# Altium schematic sheet RSSI.SchDoc(U_RSSI)
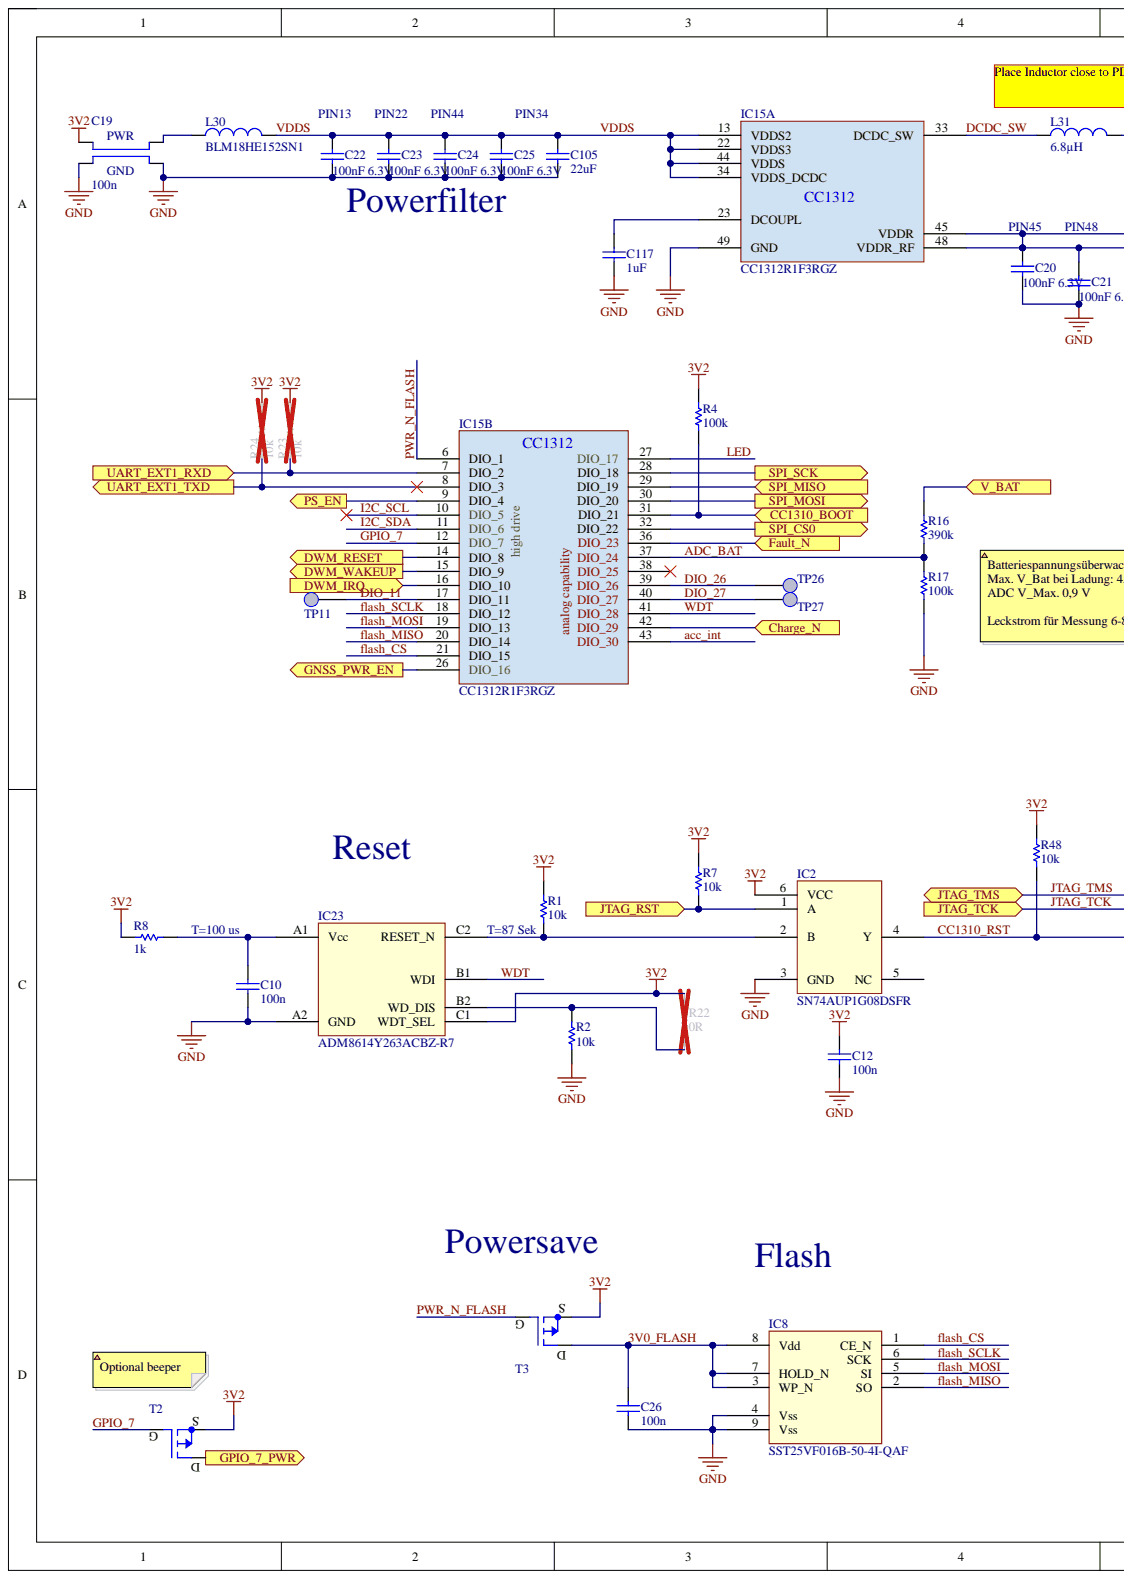
[diagram p0: RSSI.SchDoc, left]
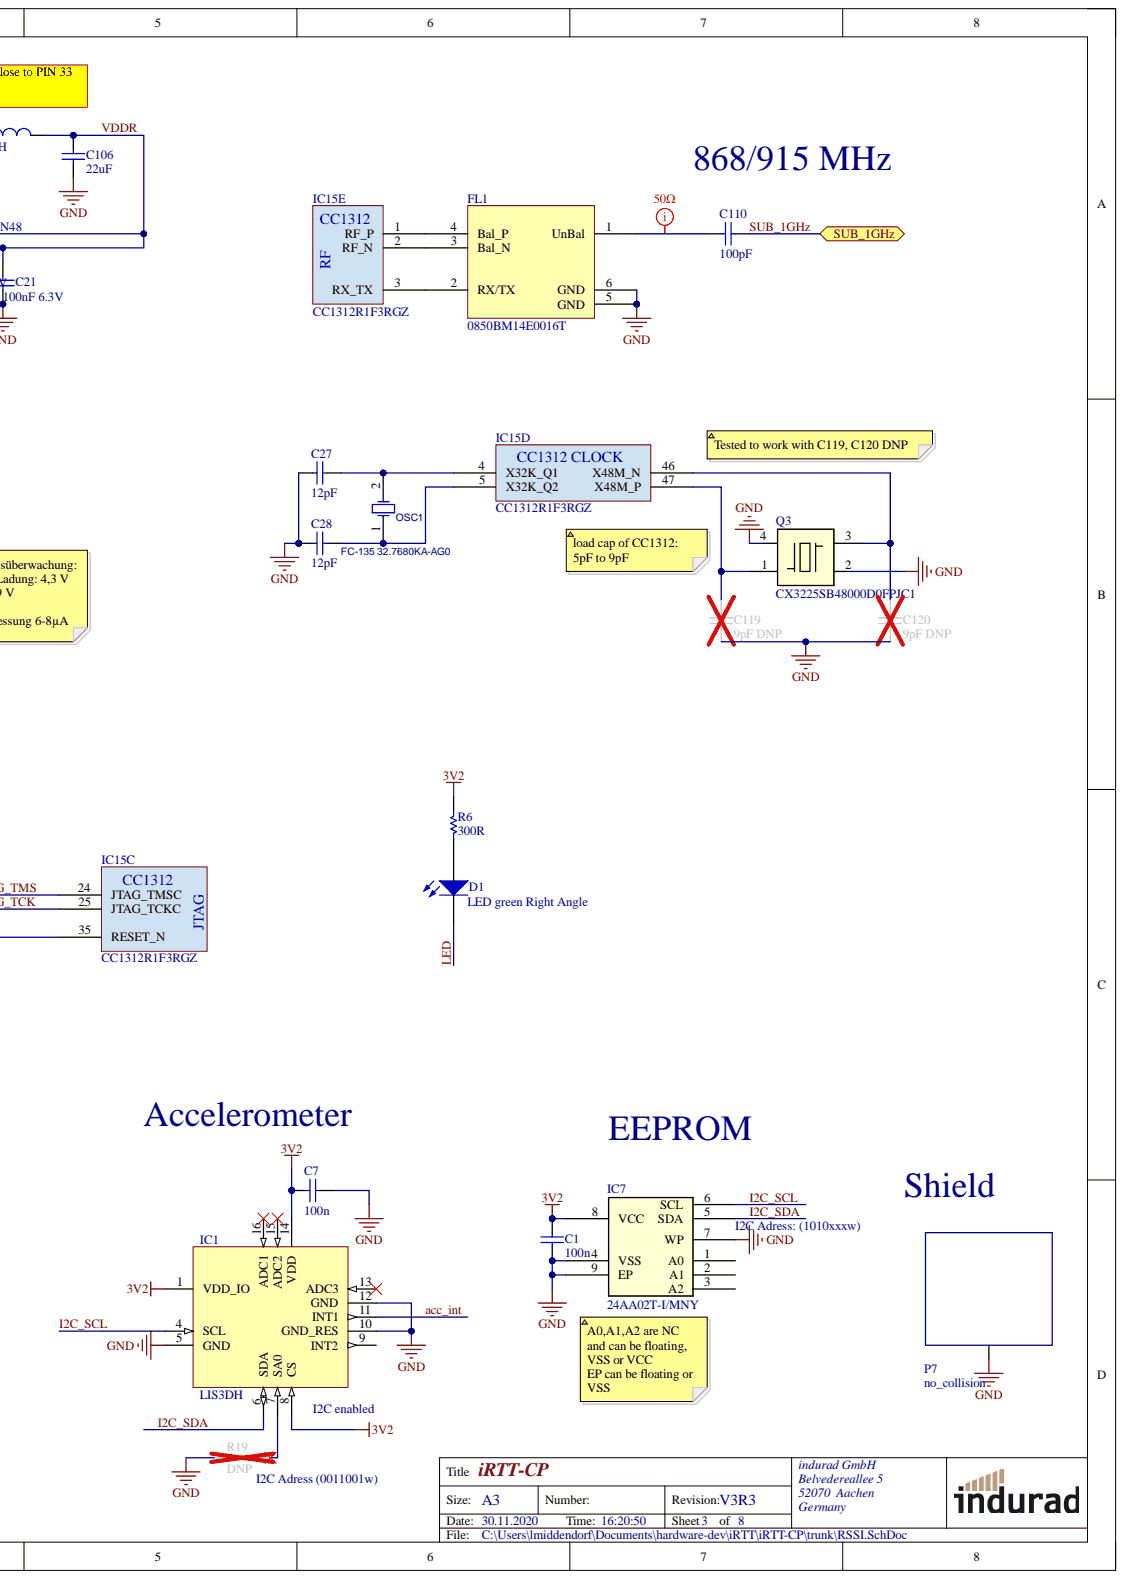
[diagram p1: RSSI.SchDoc, right]

## Parts
C1  100n  [0402_N_(1005)]
C7  100n  [0402_N_(1005)]
C10  100n  [0402_N_(1005)]
C12  100n  [0402_N_(1005)]
C19  100n  [0603_N_(1608) - 4_POL]
C20  100nF 6.3V  [0201_N_(0603)]
C21  100nF 6.3V  Voltage - Rated: 6.3V  [0201_N_(0603)]
C22  100nF 6.3V  [0201_N_(0603)]
C23  100nF 6.3V  Voltage - Rated: 6.3V  [0201_N_(0603)]
C24  100nF 6.3V  Voltage - Rated: 6.3V  [0201_N_(0603)]
C25  100nF 6.3V  Voltage - Rated: 6.3V  [0201_N_(0603)]
C26  100n  [0402_N_(1005)]
C27  12pF  [0402_N_(1005)]
C28  12pF  [0402_N_(1005)]
C105  22uF  Voltage - Rated: 6.3V  [0603_N_(1608)]
C106  22uF  [0603_N_(1608)]
C110  100pF  [0402_N_(1005)]
C117  1uF  [0402_N_(1005)]
C119  9pF DNP  value 9pF  Capacitance: 9pF  [0402_N_(1005)]
C120  9pF DNP  value 9pF  Capacitance: 9pF  [0402_N_(1005)]
D1  LED green Right Angle  [Right Angle Surface LED - HighDensity]
FL1  0850BM14E0016T  [0603 6pol]
IC1  LIS3DH  [LGA16_3mmx3mmx1mm]
IC2  SN74AUP1G08DSFR  [SON6]
IC7  24AA02T-I/MNY  [8-TDFN - EP]
IC8  SST25VF016B-50-4I-QAF  [WDFN-8 WSON-8]
IC15  CC1312R1F3RGZ  [QFN48]  (parts A,B,C,D,E)
IC23  ADM8614Y263ACBZ-R7  [6-WFBGA]
L30  BLM18HE152SN1  [INDC_0603_L_(1608)]
L31  6.8µH  [INDC_0805_L_(2012)]
OSC1  FC-135 32.7680KA-AG0  [FC-135R]
P7  ClassName: no_collision  [SMD Shielding Cabinet 15X15 with clips_CC1312]  (hidden comment: SMD Shield with clips 15x15x2x0,20)
Q3  CX3225SB48000D0FPJC1  [Quarz-MT]
R1  10k  [0402_N_(1005)]
R2  10k  [0402_N_(1005)]
R4  100k  [0402_N_(1005)]
R6  300R  [0402_N_(1005)]
R7  10k  [0402_N_(1005)]
R8  1k  [0402_N_(1005)]
R16  390k  [0603_N_(1608)]
R17  100k  [0402_N_(1005)]
R19  DNP  [0402_N_(1005)]
R22  0R  [0603_N_(1608)]
R23  10k  [0402_N_(1005)]
R24  10k  [0402_N_(1005)]
R48  10k  [0402_N_(1005)]
T2  P-MOSFET  [SOT883]  (value not drawn)
T3  P-MOSFET  [SOT883]  (value not drawn)
TP11  TP  [TP1.0d0.7]  (no BOM)
TP26  TP  [TP1.0d0.7]  (no BOM)
TP27  TP  [TP1.0d0.7]  (no BOM)

## Ports
CC1310_BOOT, Charge_N, DWM_IRQ, DWM_RESET, DWM_WAKEUP, Fault_N, GNSS_PWR_EN, GPIO_7_PWR, JTAG_RST, JTAG_TCK, JTAG_TMS, PS_EN, SPI_CS0, SPI_MISO, SPI_MOSI, SPI_SCK, SUB_1GHz, UART_EXT1_RXD, UART_EXT1_TXD, V_BAT

## Nets
3V2: C1.2 C7.2 C12.2 C19.1(PWR) IC1.1(VDD_IO) IC1.8(CS) IC1.14(VDD) IC2.6(VCC) IC7.8(VCC) IC23.C1(WDT_SEL) R1.1 R4.2 R6.2 R7.2 R8.1 R22.2 R23.2 R24.2 R48.2 T2.2 T3.2
GND: C1.1 C7.1 C10.1 C12.1 C19.2 C19.4 C20.2 C21.2 C22.2 C23.2 C24.2 C25.2 C26.1 C27.1 C28.1 C105.1 C106.1 C117.1 C119.1 C120.1 FL1.5(GND) FL1.6(GND) IC1.5(GND) IC1.10(GND_RES) IC1.12(GND) IC2.3(GND) IC7.4(VSS) IC7.7(WP) IC7.9(EP) IC8.4(Vss) IC8.9(Vss) IC15.49(GND) IC23.A2(GND) P7.1 Q3.2 Q3.4 R2.2 R17.1 R19.2
3V0_FLASH: C26.2 IC8.3(WP_N) IC8.7(HOLD_N) IC8.8(Vdd) T3.3
acc_int: IC1.11(INT1) IC15.43(DIO_30)
ADC_BAT: IC15.37(DIO_24) R16.1 R17.2
CC1310_BOOT: IC15.31(DIO_21) R4.1 ; port CC1310_BOOT
CC1310_RST: IC2.4(Y) IC15.35(RESET_N) R48.1
Charge_N: IC15.42(DIO_29) ; port Charge_N
DCDC_SW: IC15.33(DCDC_SW) L31.1
DIO_11: IC15.17(DIO_11) TP11.1
DIO_26: IC15.39(DIO_26) TP26.1
DIO_27: IC15.40(DIO_27) TP27.1
DWM_IRQ: IC15.16(DIO_10) ; port DWM_IRQ
DWM_RESET: IC15.14(DIO_8) ; port DWM_RESET
DWM_WAKEUP: IC15.15(DIO_9) ; port DWM_WAKEUP
Fault_N: IC15.36(DIO_23) ; port Fault_N
flash_CS: IC8.1(CE_N) IC15.21(DIO_15)
flash_MISO: IC8.2(SO) IC15.20(DIO_14)
flash_MOSI: IC8.5(SI) IC15.19(DIO_13)
flash_SCLK: IC8.6(SCK) IC15.18(DIO_12)
GNSS_PWR_EN: IC15.26(DIO_16) ; port GNSS_PWR_EN
GPIO_7: IC15.12(DIO_7) T2.1
GPIO_7_PWR: T2.3 ; port GPIO_7_PWR
I2C_SCL: IC1.4(SCL) IC7.6(SCL) IC15.10(DIO_5)
I2C_SDA: IC1.6(SDA) IC7.5(SDA) IC15.11(DIO_6)
JTAG_RST: IC2.1(A) R7.1 ; port JTAG_RST
JTAG_TCK: IC15.25(JTAG_TCKC) ; port JTAG_TCK
JTAG_TMS: IC15.24(JTAG_TMSC) ; port JTAG_TMS
LED: D1.1 IC15.27(DIO_17)
PS_EN: IC15.9(DIO_4) ; port PS_EN
PWR_N_FLASH: IC15.6(DIO_1) T3.1
SPI_CS0: IC15.32(DIO_22) ; port SPI_CS0
SPI_MISO: IC15.29(DIO_19) ; port SPI_MISO
SPI_MOSI: IC15.30(DIO_20) ; port SPI_MOSI
SPI_SCK: IC15.28(DIO_18) ; port SPI_SCK
SUB_1GHz: C110.1 ; port SUB_1GHz
UART_EXT1_RXD: IC15.7(DIO_2) R23.1 ; port UART_EXT1_RXD
UART_EXT1_TXD: IC15.8(DIO_3) R24.1 ; port UART_EXT1_TXD
V_BAT: R16.2 ; port V_BAT
VDDR: C20.1 C21.1 C106.2 IC15.45(VDDR) IC15.48(VDDR_RF) L31.2
VDDS: C22.1 C23.1 C24.1 C25.1 C105.2 IC15.13(VDDS2) IC15.22(VDDS3) IC15.34(VDDS_DCDC) IC15.44(VDDS) L30.2
WDT: IC15.41(DIO_28) IC23.B1(WDI)
NetC10_2: C10.2 IC23.A1(Vcc) R8.2
NetC19_3: C19.3 L30.1
NetC27_2: C27.2 IC15.4(X32K_Q1) OSC1.2
NetC28_2: C28.2 IC15.5(X32K_Q2) OSC1.1
NetC110_2: C110.2 FL1.1(UnBal)
NetC117_2: C117.2 IC15.23(DCOUPL)
NetC119_2: C119.2 IC15.47(X48M_P) Q3.1
NetC120_2: C120.2 IC15.46(X48M_N) Q3.3
NetD1_2: D1.2 R6.1
NetFL1_2: FL1.2(RX/TX) IC15.3(RX_TX)
NetFL1_3: FL1.3(Bal_N) IC15.2(RF_N)
NetFL1_4: FL1.4(Bal_P) IC15.1(RF_P)
NetIC1_7: IC1.7(SA0) R19.1
NetIC1_9: IC1.9(INT2)
NetIC1_13: IC1.13(ADC3)
NetIC1_15: IC1.15(ADC2)
NetIC1_16: IC1.16(ADC1)
NetIC2_2: IC2.2(B) IC23.C2(RESET_N) R1.2
NetIC2_5: IC2.5(NC)
NetIC7_1: IC7.1(A0)
NetIC7_2: IC7.2(A1)
NetIC7_3: IC7.3(A2)
NetIC15_38: IC15.38(DIO_25)
NetIC23_B2: IC23.B2(WD_DIS) R2.1 R22.1

## Library data of the parts
C1 C7 C10 C12 C26 = Cap: Capacitor; Manufacturer: Murata Electronics North America; Manufacturer Part Number: GRM155R70J104KA01D; Capacitance: 0.1µF; Temperature Coefficient: X7R; Tolerance: ±10%; Voltage - Rated: 6.3V; Applications: General Purpose; Category: Capacitors; Component Kind: Standard; ComponentLink1Description: http://www.murata.com/~/media/webrenewal/support/library/catalog/products/capacitor/mlcc/c02e.ashx; ComponentLink1URL: http://www.murata.com/~/media/webrenewal/support/library/catalog/products/capacitor/mlcc/c02e.ashx; Features: -; Height - Seated (Max): -; Lead Spacing: -; Mounting Type: Surface Mount, MLCC; Operating Temperature: -55°C ~ 125°C; Package / Case: 0402 (1005 Metric); Packaging: Cut Tape (CT); Pricing 1: 1=0.1, 10=0.025, 50=0.0136, 100=0.0114, 250=0.00936, 500=0.008, 1000=0.00622, 5000=0.00519 (EUR); Ratings: -; RoHS: RoHS Compliant; Signal Integrity: Cap; Simulation: CAP; Size / Dimension: 0.039" L x 0.020" W (1.00mm x 0.50mm); Stock 1: 430852; Supplier 1: Digi-Key; Supplier Part Number 1: 490-6319-1-ND; Thickness (Max): 0.022" (0.55mm); Value: 100n; Library Name: Indurad.SchLib
C19 = Cap 4 Pol 16V: Capacitor 4 pol 16V; Manufacturer: TDK Corporation; Manufacturer Part Number: YFF18PC1C104M; Capacitance: 0.1µF; Current: 4A; Category: Filters; Component Kind: Standard; ComponentLink1Description: https://product.tdk.com/info/en/catalog/datasheets/emc_esr_yff-p_en.pdf; ComponentLink1URL: https://product.tdk.com/info/en/catalog/datasheets/emc_esr_yff-p_en.pdf; DC Resistance (DCR): -; Height (Max): 0.035" (0.90mm); Operating Temperature: -55°C ~ 125°C; Package / Case: 0603 (1608 Metric), 4 PC Pad; Packaging: Cut Tape (CT); Pricing 1: 1=0.48, 10=0.46, 100=0.223, 250=0.18524, 500=0.16806, 1000=0.15434 (EUR); RoHS: RoHS Compliant; Size / Dimension: 0.063" L x 0.031" W (1.60mm x 0.80mm); Stock 1: 4170; Supplier 1: Digi-Key; Supplier Part Number 1: 445-16133-1-ND; Value: 100n; Voltage: 16V; Library Name: Indurad.SchLib
C20-C25 = Cap: Capacitor; Manufacturer: TDK Corporation; Manufacturer Part Number: C0603X5R0J104K030BC; Capacitance: 0.10µF; Temperature Coefficient: X5R; Tolerance: ±10%; Applications: General Purpose; Category: Capacitors; Component Kind: Standard; ComponentLink1Description: https://product.tdk.com/info/en/catalog/datasheets/mlcc_commercial_general_en.pdf; ComponentLink1URL: https://product.tdk.com/info/en/catalog/datasheets/mlcc_commercial_general_en.pdf; Features: -; Height - Seated (Max): -; Lead Spacing: -; Lead Style: -; Mounting Type: Surface Mount, MLCC; Operating Temperature: -55°C ~ 85°C; Package / Case: 0201 (0603 Metric); Packaging: Cut Tape (CT); Pricing 1: 1=0.09, 10=0.028, 100=0.0131, 250=0.01072, 500=0.00916, 1000=0.00713, 5000=0.00594 (EUR); Ratings: -; RoHS: RoHS Compliant; Signal Integrity: Cap; Simulation: CAP; Size / Dimension: 0.024" L x 0.012" W (0.60mm x 0.30mm); Stock 1: 1826831; Supplier 1: Digi-Key; Supplier Part Number 1: 445-1796-1-ND; Thickness (Max): 0.013" (0.33mm); Value: 100nF 6.3V; Library Name: Indurad.SchLib
  C20 C22: Voltage - Rated: 6.3V
C27 C28 = Cap: Capacitor; Manufacturer: Murata Electronics North America; Manufacturer Part Number: GRM1555C1H120GA01D; Temperature Coefficient: C0G, NP0; Tolerance: ±2%; Voltage - Rated: 50V; Applications: General Purpose; Capacitance: 12pF; Category: Capacitors; Component Kind: Standard; ComponentLink1Description: http://www.murata.com/~/media/webrenewal/support/library/catalog/products/capacitor/mlcc/c02e.pdf; ComponentLink1URL: http://www.murata.com/~/media/webrenewal/support/library/catalog/products/capacitor/mlcc/c02e.pdf; Features: -; Height - Seated (Max): -; Lead Spacing: -; Lead Style: -; Mounting Type: Surface Mount, MLCC; Operating Temperature: -55°C ~ 125°C; Package / Case: 0402 (1005 Metric); Packaging: Cut Tape (CT); Pricing 1: 1=0.09, 10=0.019, 50=0.0104, 100=0.0087, 250=0.00716, 500=0.00614, 1000=0.00477, 5000=0.00398 (EUR); Ratings: -; RoHS: RoHS Compliant; Signal Integrity: Cap; Simulation: CAP; Size / Dimension: 0.039" L x 0.020" W (1.00mm x 0.50mm); Stock 1: 156037; Supplier 1: Digi-Key; Supplier Part Number 1: 490-6197-1-ND; Thickness (Max): 0.022" (0.55mm); Value: 12pF; Library Name: Indurad.SchLib
C105 = Cap: Capacitor; Manufacturer: Murata Electronics North America; Manufacturer Part Number: GRM188R60J226MEA0D; Capacitance: 22µF; Temperature Coefficient: X5R; Tolerance: ±20%; Applications: General Purpose; Category: Capacitors; Component Kind: Standard; ComponentLink1Description: http://www.murata.com/~/media/webrenewal/support/library/catalog/products/capacitor/mlcc/c02e.ashx; ComponentLink1URL: http://www.murata.com/~/media/webrenewal/support/library/catalog/products/capacitor/mlcc/c02e.ashx; Features: Derating Recommended; Height - Seated (Max): -; Lead Spacing: -; Lead Style: -; Mounting Type: Surface Mount, MLCC; Operating Temperature: -55°C ~ 85°C; Package / Case: 0603 (1608 Metric); Packaging: Cut Tape (CT); Pricing 1: 1=0.24, 10=0.193, 50=0.1086, 100=0.0965, 250=0.0724, 500=0.06396, 1000=0.05672 (EUR); Ratings: -; RoHS: RoHS Compliant; Signal Integrity: Cap; Simulation: CAP; Size / Dimension: 0.063" L x 0.031" W (1.60mm x 0.80mm); Stock 1: 0; Supplier 1: Digi-Key; Supplier Part Number 1: 490-7611-1-ND; Thickness (Max): 0.039" (1.00mm); Value: 22uF; Library Name: Indurad.SchLib
C106 = Cap: Capacitor; Manufacturer: Murata Electronics North America; Manufacturer Part Number: GRM188R60J226MEA0D; Capacitance: 22µF; Temperature Coefficient: X5R; Tolerance: ±20%; Voltage - Rated: 6.3V; Applications: General Purpose; Category: Capacitors; Component Kind: Standard; ComponentLink1Description: http://www.murata.com/~/media/webrenewal/support/library/catalog/products/capacitor/mlcc/c02e.ashx; ComponentLink1URL: http://www.murata.com/~/media/webrenewal/support/library/catalog/products/capacitor/mlcc/c02e.ashx; Features: Derating Recommended; Height - Seated (Max): -; Lead Spacing: -; Lead Style: -; Mounting Type: Surface Mount, MLCC; Operating Temperature: -55°C ~ 85°C; Package / Case: 0603 (1608 Metric); Packaging: Cut Tape (CT); Pricing 1: 1=0.24, 10=0.193, 50=0.1086, 100=0.0965, 250=0.0724, 500=0.06396, 1000=0.05672 (EUR); Ratings: -; RoHS: RoHS Compliant; Signal Integrity: Cap; Simulation: CAP; Size / Dimension: 0.063" L x 0.031" W (1.60mm x 0.80mm); Stock 1: 0; Supplier 1: Digi-Key; Supplier Part Number 1: 490-7611-1-ND; Thickness (Max): 0.039" (1.00mm); Value: 22uF; Library Name: Indurad.SchLib
C110 = Cap: Capacitor; Manufacturer: Murata Electronics North America; Manufacturer Part Number: GRM1555C1H101JA01D; Temperature Coefficient: C0G, NP0; Tolerance: ±5%; Voltage - Rated: 50V; Applications: General Purpose; Capacitance: 100pF; Category: Capacitors; Component Kind: Standard; ComponentLink1Description: http://www.murata.com/~/media/webrenewal/support/library/catalog/products/capacitor/mlcc/c02e.ashx; ComponentLink1URL: http://www.murata.com/~/media/webrenewal/support/library/catalog/products/capacitor/mlcc/c02e.ashx; Features: -; Height - Seated (Max): -; Lead Spacing: -; Lead Style: -; Mounting Type: Surface Mount, MLCC; Operating Temperature: -55°C ~ 125°C; Package / Case: 0402 (1005 Metric); Packaging: Cut Tape (CT); Pricing 1: 1=0.2, 10=0.026, 50=0.0142, 100=0.0119, 250=0.0098, 500=0.00836, 1000=0.00651, 5000=0.00542 (EUR); Ratings: -; RoHS: RoHS Compliant; Signal Integrity: Cap; Simulation: CAP; Size / Dimension: 0.039" L x 0.020" W (1.00mm x 0.50mm); Stock 1: 1448584; Supplier 1: Digi-Key; Supplier Part Number 1: 490-5922-1-ND; Thickness (Max): 0.022" (0.55mm); Value: 100pF; Library Name: Indurad.SchLib
C117 = Cap: Capacitor; Manufacturer: AVX; Manufacturer Part Number: 0402ZD105KAT2A; Betriebstemperatur: -55°C bis +85; Betriebstemperatur, max.: 85; Betriebstemperatur, min.: -55; Dielektrikum: X5; Kapazität: 1; Kapazitätstoleranz: ± 10%; Keramikkondensatorgehäuse: 0402 [Metrisch 1005]; Kondensatoranschlüsse: SMD<!--FANDB--><div class="features-and-benefits-container"><div class="features-and-benefits-description"><span class="features-benefits-value">The 0402ZD105KAT2A is a Multilayer Ceramic Capacitor with Ni/Sn-plated termination, X5R dielectric and 0402 case. A multilayer ceramic (MLC) capacitor is a monolithic block of ceramic containing two sets of offset, interleaved planar electrodes that extend to two opposite surfaces of the ceramic dielectric. Suitable for decoupling and filtering applications.</span></div><div class="features-and-benefits-list"><ul class="features-and-benefits-list-list"><li class="features-and-benefits-list-item">EIA Class II dielectric</li><li class="features-and-benefits-list-item">EIA 0101, 0201, 0402, 0603, 0805, 1206, 1210, 1812 case sizes available</li><li class="features-and-benefits-list-item">Rated DC voltage of 4V, 6.3V, 10V, 16V, 25V, 35V, 50V, 100V</li><li class="features-and-benefits-list-item">±10% and ±20% tolerance</li><li class="features-and-benefits-list-item">Nickel (Ni) and tin (Sn) plated terminations</li><li class="features-and-benefits-list-item">Load life of 1000h at 85?C</li><li class="features-and-benefits-list-item">Operating temperature range -55?C to 85; Nennspannung: 10; SVHC: No SVHC (15-Jun-2015); Verpackung: Gurtabschnitt; Component Kind: Standard; ComponentLink1Description: http://www.farnell.com/datasheets/1796776.pdf; ComponentLink1URL: http://www.farnell.com/datasheets/1796776.pdf; Kapazitätstoleranz ±: ± 10%; MSL: MSL 1 - unbegrenzt; Pricing 1: 10=0,0503, 300=0,0378, 1000=0,0189, 2500=0,0126, 5000=0,0108 (EUR); Produktpalette: -; RoHS: YES; Signal Integrity: Cap; Simulation: CAP; Stock 1: 29689; Supplier 1: Farnell; Supplier Part Number 1: 1740588; Value: 1uF; Library Name: Indurad.SchLib
C119 C120 = Cap: Capacitor; Temperature Coefficient: C0G, NP0; Voltage - Rated: 50V; Applications: General Purpose; Category: Capacitors; Component Kind: Standard; ComponentLink1Description: http://www.murata.com/~/media/webrenewal/support/library/catalog/products/capacitor/mlcc/c02e.pdf; ComponentLink1URL: http://www.murata.com/~/media/webrenewal/support/library/catalog/products/capacitor/mlcc/c02e.pdf; Features: -; Height - Seated (Max): -; Mounting Type: Surface Mount, MLCC; Operating Temperature: -55°C ~ 125°C; Package / Case: 0402 (1005 Metric); Signal Integrity: Cap; Simulation: CAP; Library Name: Indurad.SchLib
D1 = LED green Right Angle: Low Current LED grün 2.1 mm x 1 mm x 0.6 mm; Manufacturer: Kingbright; Manufacturer Part Number: APA2107LZGCK; Current - Test: 2mA; Lens Color: Colorless; Lens Style/Size: Rectangle with Domed Top, 1.70mm x 0.60mm; Lens Transparency: Clear; Millicandela Rating: 40mcd; Viewing Angle: 120°; Voltage - Forward (Vf) (Typ): 2.65V; Wavelength - Dominant: 525nm; Wavelength - Peak: 515nm; Category: Optoelectronics; Color: Green; Component Kind: Standard; ComponentLink1Description: http://www.kingbrightusa.com/images/catalog/SPEC/APA2107LZGCK.pdf; ComponentLink1URL: http://www.kingbrightusa.com/images/catalog/SPEC/APA2107LZGCK.pdf; Features: -; Height (Max): 0.60mm; Mounting Type: Surface Mount, Right Angle; Package / Case: 2-SMD, No Lead; Packaging: Cut Tape (CT); Part Status: Active; Pricing 1: 1=0.51, 10=0.401, 25=0.3348, 100=0.2883, 250=0.25744, 500=0.22138, 1000=0.17093 (EUR); RoHS: RoHS Compliant; Size / Dimension: 2.10mm L x 1.00mm W; Stock 1: 1787; Supplier 1: Digi-Key; Supplier Device Package: 2-SMD; Supplier Part Number 1: 754-1924-1-ND; Library Name: Indurad.SchLib
FL1 = 0850BM14E0016T: BALUN 770MHZ-928MHZ 50/50 0603; Manufacturer: Johanson; Case Code (Imperial): 0603; Case Code (Metric): 1608; Case/Package: 0603; Component Kind: Standard; ComponentLink2Description: Datasheet; ComponentLink2URL: https://www.johansontechnology.com/datasheets/0850BM14E0016/0850BM14E0016.pdf; Library Name: FL_Filter.SchLib; Manufacturer Part Number: 0850BM14E0016T; Mount: Surface Mount; Packaging: Cut Tape; Supplier 1: Digi-Key; Supplier 2: Mouser; Supplier Location 1: US; Supplier Location 2: US; Supplier Packaging 1: Cut Tape; Supplier Packaging 2: Tape & Reel; Supplier Part Number 1: 712-1621-1-ND; Supplier Part Number 2: 609-0850BM14E0016T; Supplier Unit Price 1: $0.23625; Supplier Unit Price 2: $0.186
IC1 = LIS3DH: ACCELEROMETER 3AXIS MEMS 16-LGA; Manufacturer: STMicroelectronics; Manufacturer Part Number: LIS3DHTR; Acceleration Range: ±2g, 4g, 8g, 16g; Axis: X, Y, Z; Bandwidth: 5kHz; Interface: I²C, SPI; Output Type: Digital; Sensitivity: 1mg/digit, 2mg/digit, 4mg/digit, 12mg/digit; Voltage - Supply: 1.71 V ~ 3.6 V; Category: Sensors, Transducers; Component Kind: Standard; ComponentLink1Description: http://www.st.com/web/en/resource/technical/document/datasheet/CD00274221.pdf; ComponentLink1URL: http://www.st.com/web/en/resource/technical/document/datasheet/CD00274221.pdf; Library Name: IC_Integrated_Circuit.SchLib; Mounting Type: Surface Mount; Package / Case: 16-VFLGA; Packaging: Tape & Reel (TR); Pricing 1: 4000=0.64545, 8000=0.62155, 12000=0.59764, 28000=0.58808, 100000=0.57373 (EUR); RoHS: RoHS Compliant; Stock 1: 36000; Supplier 1: Digi-Key; Supplier 2: Future Electronics; Supplier 3: Mouser; Supplier 4: Arrow; Supplier 5: Farnell; Supplier Device Package: 16-LGA (3x3); Supplier Part Number 1: 497-10613-2-ND; Supplier Part Number 2: LIS3DHTR-CUTT; Supplier Part Number 3: 511-LIS3DHTR; Supplier Part Number 4: LIS3DHTR; Supplier Part Number 5: 2253507
IC2 = SN74AUP1G08DSFR: low-Power Single  2-Input  Positive-AND  Gate; Manufacturer: Texas Instruments; Current - Output High, Low: 4mA, 4mA; Current - Quiescent (Max): 0.5µA; Logic Level - High: 1.6 V ~ 2 V; Logic Level - Low: 0.7 V ~ 0.9 V; Max Propagation Delay @ V, Max CL: 6.2ns @ 3.3V, 30pF; Number of Circuits: 1; Number of Inputs: 2; Voltage - Supply: 0.8 V ~ 3.6 V; Category: Integrated Circuits (ICs); Component Kind: Standard; ComponentLink1Description: http://www.ti.com/lit/ds/symlink/sn74aup1g08.pdf; ComponentLink1URL: http://www.ti.com/lit/ds/symlink/sn74aup1g08.pdf; Features: -; Logic Type: AND Gate; Manufacturer Part Number: SN74AUP1G08DSFR; Mounting Type: Surface Mount; Operating Temperature: -40°C ~ 85°C; Package / Case: 6-XFDFN; Packaging: Cut Tape (CT); Part Status: Active; Pricing 1: 1=0.35, 10=0.283, 100=0.193, 500=0.14448, 1000=0.1082 (EUR); RoHS: RoHS Compliant; Stock 1: 6912; Supplier 1: Digi-Key; Supplier Device Package: 6-SON (1x1); Supplier Part Number 1: 296-25616-1-ND; Library Name: Indurad.SchLib
IC7 = 24AA02T-I/MNY: IC EEPROM 2K I2C 400KHZ 8TDFN; Manufacturer: Microchip; Access Time: 3500ns; Density: 2Kb; Interface: I2C,Serial; Max Frequency: 100kHz; Max Supply Voltage: 5.5V; Min Supply Voltage: 1.7V; Nominal Supply Current: 3mA; Number of Words: 256; Operating Supply Voltage: 5V; Category: EEPROM; Component Kind: Standard; ComponentLink1Description: Datasheet; ComponentLink1URL: http://ww1.microchip.com/downloads/en/DeviceDoc/24AA02-24LC02B-24FC02-Data-Sheet-20001709L.pdf; ComponentLink2Description: Product Page; ComponentLink2URL: https://www.microchip.com/wwwproducts/en/24AA02; Frequency: 400kHz; Height: 0.75mm; Lead Free: Lead Free; Length: 3mm; Library Name: IC_Integrated_Circuit.SchLib; Manufacturer Part Number: 24AA02T-I/MNY; Max Operating Temperature: 85°C; Memory Size: 2Kb; Memory Type: EEPROM; Min Operating Temperature: -40°C; Mount: Surface Mount; Number of Pins: 8; Package Quantity: 3300; Packaging: Tape and Reel; Radiation Hardening: No; Supplier 1: Digi-Key; Supplier 2: Mouser; Supplier Location 1: US; Supplier Location 2: US; Supplier Packaging 1: Cut Tape; Supplier Packaging 2: Tape & Reel; Supplier Part Number 1: 24AA02T-I/MNYCT-ND; Supplier Part Number 2: 579-24AA02T-I/MNY; Supplier Unit Price 1: $0.2543; Supplier Unit Price 2: $0.222; Width: 2mm
IC8 = SST25VF016B-50-4I-QAF: Flash-Speicher 2.7V to 3.6V 16Mbit SPI Serial Flash; Manufacturer: Microchip Technology; Memory Size: 16M (2M x 8); Memory Type: FLASH - NOR; Organization: 1 M x 8; Speed: 50MHz; Voltage - Supply: 2.7 V ~ 3.6 V; Category: Integrated Circuits (ICs); Component Kind: Standard; ComponentLink1Description: http://www.microchip.com/mymicrochip/filehandler.aspx?ddocname=en550401; ComponentLink1URL: http://www.microchip.com/mymicrochip/filehandler.aspx?ddocname=en550401; Format - Memory: FLASH; Interface: SPI Serial; Manufacturer Part Number: SST25VF016B-50-4I-QAF; Operating Temperature: -40°C ~ 85°C (TA); Package / Case: 8-WDFN Exposed Pad; Packaging: Tube; Part Status: Active; Pricing 1: 1=1.49 (EUR); RoHS: RoHS Compliant; Stock 1: 494; Supplier 1: Mouser; Supplier Device Package: 8-WSON (6x5); Supplier Part Number 1: SST25VF016B-50-4I-QAF; Library Name: Indurad.SchLib
IC15 = CC1312R1F3RGZ_split: Sub-1 GHz Ultra-Low Power Wireless Microcontroller; Manufacturer: Texas Instruments; Component Kind: Standard; ComponentLink1Description: Product Page; ComponentLink1URL: http://www.ti.com/product/CC1312R; ComponentLink2Description: Data Sheet; ComponentLink2URL: http://www.ti.com/lit/gpn/cc1312r; Library Name: UC_Microcontroller.SchLib; Manufacturer Part Number: CC1312R1F3RGZ; Supplier 1: Mouser; Supplier 2: Mouser; Supplier Part Number 1: 595-CC1312R1F3RGZT; Supplier Part Number 2: 595-CC1312R1F3RGZR
IC23 = ADM8614Y263ACBZ-R7: Watchdog; Manufacturer: Analog Devices Inc.; Number of Voltages Monitored: 1; Output: Open Drain or Open Collector; Reset: Active Low; Reset Timeout: 200 ms Typical; Voltage - Threshold: 2.63V; Category: Integrated Circuits (ICs); Component Kind: Standard; ComponentLink1Description: http://www.analog.com/media/en/technical-documentation/data-sheets/ADM8611_8612_8613_8614_8615.pdf; ComponentLink1URL: http://www.analog.com/media/en/technical-documentation/data-sheets/ADM8611_8612_8613_8614_8615.pdf; Manufacturer Part Number: ADM8614Y263ACBZ-R7; Mounting Type: Surface Mount; Operating Temperature: -40°C ~ 85°C (TA); Package / Case: 6-WFBGA, CSPBGA; Packaging: Digi-Reel®; Part Status: Active; Pricing 1: 1=1.57, 10=1.413, 100=1.1336, 500=0.9317, 1000=0.77124 (EUR); RoHS: RoHS Compliant; Stock 1: 2705; Supplier 1: Digi-Key; Supplier Device Package: 6-WLCSP (1.0x1.5); Supplier Part Number 1: ADM8614Y263ACBZ-R7DKR-ND; Type: Simple Reset/Power-On Reset; Library Name: Indurad.SchLib
L30 = Inductor: Manufacturer: MURATA; Manufacturer Part Number: BLM18HE152SN1D; Bauform: 0603 [Metrisch 1608]; DC-Nennstrom: 500; DC-Widerstand, max.: 0.5; Ferritmontage: Oberflächenmontage; Impedanz bei 100MHz: 1.5; Produktpalette: Baureihe BLM18; SVHC: No SVHC (15-Jun-2015); Toleranz der Impedanz: ± 25%; Component Kind: Standard; ComponentLink1Description: http://www.farnell.com/datasheets/1851896.pdf; ComponentLink1URL: http://www.farnell.com/datasheets/1851896.pdf; Description: Inductor; MSL: MSL 1 - unbegrenzt<!--FANDB--><div class="features-and-benefits-container"><div class="features-and-benefits-description"><span class="features-benefits-value">The BLM18HE152SN1D is a SMD Chip Ferrite Bead with 0603 case. It realizes high impedance at 1GHz and suitable for noise suppression from 500MHz to GHz range. The impedance value of HG/HD-type is about three times as large as that of A/B-type at 1GHz, though the impedance characteristic of HG/HD-type is similar to A/B-type at 100MHz or less.</span></div><div class="features-and-benefits-list"><ul class="features-and-benefits-list-list"><li class="features-and-benefits-list-item">-55 to 125; Pricing 1: 10=0,101, 150=0,0743, 400=0,0624, 1000=0,0422, 2000=0,0355, 4000=0,0317, 20000=0,0279, 40000=0,0273 (EUR); RoHS: YES; Signal Integrity: Inductor; Simulation: INDUCTOR; Stock 1: 14543; Supplier 1: Farnell; Supplier Part Number 1: 2443237; Value: BLM18HE152SN1; Library Name: Indurad.SchLib
L31 = Inductor: Manufacturer: TDK Corporation; Manufacturer Part Number: MLZ2012N6R8LT000; Current - Saturation: 110mA; Current Rating: 550mA; DC Resistance (DCR): 325 mOhm Max; Frequency - Self Resonant: 60MHz; Frequency - Test: 2MHz; Material - Core: Ferrite; Shielding: Shielded; Tolerance: ±20%; Type: Multilayer; Category: Inductors, Coils, Chokes; Component Kind: Standard; ComponentLink1Description: https://product.tdk.com/info/en/catalog/datasheets/inductor_commercial_decoupling_mlz2012_en.pdf; ComponentLink1URL: https://product.tdk.com/info/en/catalog/datasheets/inductor_commercial_decoupling_mlz2012_en.pdf; Description: Inductor; Height - Seated (Max): 0.057" (1.45mm); Inductance: 6.8µH; Mounting Type: Surface Mount; Operating Temperature: -55°C ~ 125°C; Package / Case: 0805 (2012 Metric); Packaging: Cut Tape (CT); Pricing 1: 1=0.13, 10=0.118, 50=0.092, 100=0.0825, 500=0.07072, 1000=0.06128 (EUR); Q @ Freq: -; RoHS: RoHS Compliant; Signal Integrity: Inductor; Simulation: INDUCTOR; Size / Dimension: 0.079" L x 0.049" W (2.00mm x 1.25mm); Stock 1: 14138; Supplier 1: Digi-Key; Supplier Device Package: 0805 (2012 Metric); Supplier Part Number 1: 445-6761-1-ND; Value: 6.8µH; Library Name: Indurad.SchLib
OSC1 = FC-135 32.7680KA-AG0: Quarz32.768KHz 20ppm 7.0pF -40C +85C; Manufacturer: EPSON; Manufacturer Part Number: FC-135R 32.7680KA-AG0; ESR (Equivalent Series Resistance): 50 kOhm; Load Capacitance: 7pF; Operating Mode: Fundamental; Category: Crystals and Oscillators; Component Kind: Standard; ComponentLink1Description: https://support.epson.biz/td/api/doc_check.php?dl=brief_FC-135R_en.pdf; ComponentLink1URL: https://support.epson.biz/td/api/doc_check.php?dl=brief_FC-135R_en.pdf; Frequency: 32.768kHz; Frequency Stability: -; Frequency Tolerance: ±20ppm; Height: 0.035" (0.90mm); Mounting Type: Surface Mount; Operating Temperature: -40°C ~ 85°C; Package / Case: 2-SMD, No Lead (DFN, LCC); Packaging: Tape & Reel (TR); Pricing 1: 1000=0.47709 (EUR); Ratings: -; RoHS: RoHS Compliant; Size / Dimension: 0.126" L x 0.061" W (3.20mm x 1.50mm); Stock 1: 0; Supplier 1: Digi-Key; Supplier Part Number 1: FC-135R 32.7680KA-AG0-ND; Type: kHz Crystal (Tuning Fork); Library Name: Indurad.SchLib
P7 = SMD Shield with clips 15x15x2x0,20: SMD Shielding Cabinet 15x15x2x0,20; Manufacturer: MTC; Manufacturer Part Number: SGH-207865 / 4X SC-6,50x0,80x1,27-TC-0,15; EMS Note: multiple parts per BOM item; Component Kind: Standard; Supplier 1: MTC; Supplier Part Number 1: SGH-207865 / 4X SC-6,50x0,80x1,27-TC-0,15; Library Name: Indurad.SchLib
Q3 = Quarz_48MHz_CX3225SB48000D0FPJC1: Quarz 48MHz 4pol 3.2mm x 2.5mm 8pF; Manufacturer: Kyocera AVX; ESR (Equivalent Series Resistance): 23R; Frequency Stability: 15ppm; Frequency Tolerance: 10ppm; Series Resistance: 50R; Case/Package: SMD/SMT; Component Kind: Standard; ComponentLink2Description: Data Sheet; ComponentLink2URL: https://media.digikey.com/pdf/Data%20Sheets/AVX%20PDFs/CX3225SB48000D0FPJC1.pdf?src-supplier=Digi-Key; Frequency: 48MHz; Height: 0.024inch; Length: 0.126inch; Library Name: Y_Oscillator.SchLib; Load Capacitance: 8pF; Manufacturer Part Number: CX3225SB48000D0FPJC1; Max Operating Temperature: 85°C; Min Operating Temperature: -40°C; Mount: Surface Mount; Package Quantity: 3000; Packaging: Tape and Reel; Ratings: AEC-Q200; REACH SVHC: No SVHC; RoHS: Yes; Supplier 1: Digi-Key; Supplier 2: Mouser; Supplier Part Number 1: 1253-1377-1-ND; Supplier Part Number 2: 581-CX3225SB480D0FPJ; Termination: SMD/SMT; Tolerance: 10ppm; Weight: 0.000564oz; Width: 0.098inch
R1 R2 R4 R6-R8 R16 R17 R19 R22-R24 R48 = Res: Resistor; Component Kind: Standard; Signal Integrity: Res; Simulation: RESISTOR; Library Name: Indurad.SchLib
  R1 R2 R7 R23 R24 R48: Value: 10k
  R4 R17: Value: 100k
  R6: Value: 300R
  R8: Value: 1k
  R16: Value: 390k
  R19: Value: DNP
  R22: Value: 0R
T2 T3 = MOSFET-P SSM3J56ACT: P-Channel MOSFET SSM3J56ACT; Manufacturer: Toshiba Semiconductor and Storage; Manufacturer Part Number: SSM3J56ACT,L3F; Current - Continuous Drain (Id) @ 25°C: 1.4A (Ta); Drive Voltage (Max Rds On,  Min Rds On): 1.2V, 4.5V; Gate Charge (Qg) (Max) @ Vgs: 1.6nC @ 4.5V; Input Capacitance (Ciss) (Max) @ Vds: 100pF @ 10V; Power Dissipation (Max): 500mW (Ta); Rds On (Max) @ Id, Vgs: 390 mOhm @ 800mA, 4.5V; Vgs (Max): ±8V; Vgs(th) (Max) @ Id: 1V @ 1mA; Category: Discrete Semiconductor Products; Component Kind: Standard; ComponentLink1Description: https://toshiba.semicon-storage.com/info/docget.jsp?did=30735&prodName=SSM3J56ACT; ComponentLink1URL: https://toshiba.semicon-storage.com/info/docget.jsp?did=30735&prodName=SSM3J56ACT; FET Feature: -; FET Type: P-Channel; Mounting Type: Surface Mount; Operating Temperature: 150°C; Package / Case: SC-101, SOT-883; Packaging: Cut Tape (CT); Part Status: Active; Pricing 1: 1=0.42, 10=0.292, 100=0.1919, 500=0.11342, 1000=0.08725 (EUR); RoHS: RoHS Compliant; Stock 1: 28265; Supplier 1: Digi-Key; Supplier Device Package: CST3; Supplier Part Number 1: SSM3J56ACTL3FCT-ND; Technology: MOSFET (Metal Oxide); Library Name: Indurad.SchLib
TP11 TP26 TP27 = TP: Generic test point; Library Name: InduradCommon.SchLib
